# Altium schematic sheet EBoard_UI_LCD16x2_r1.SchDoc
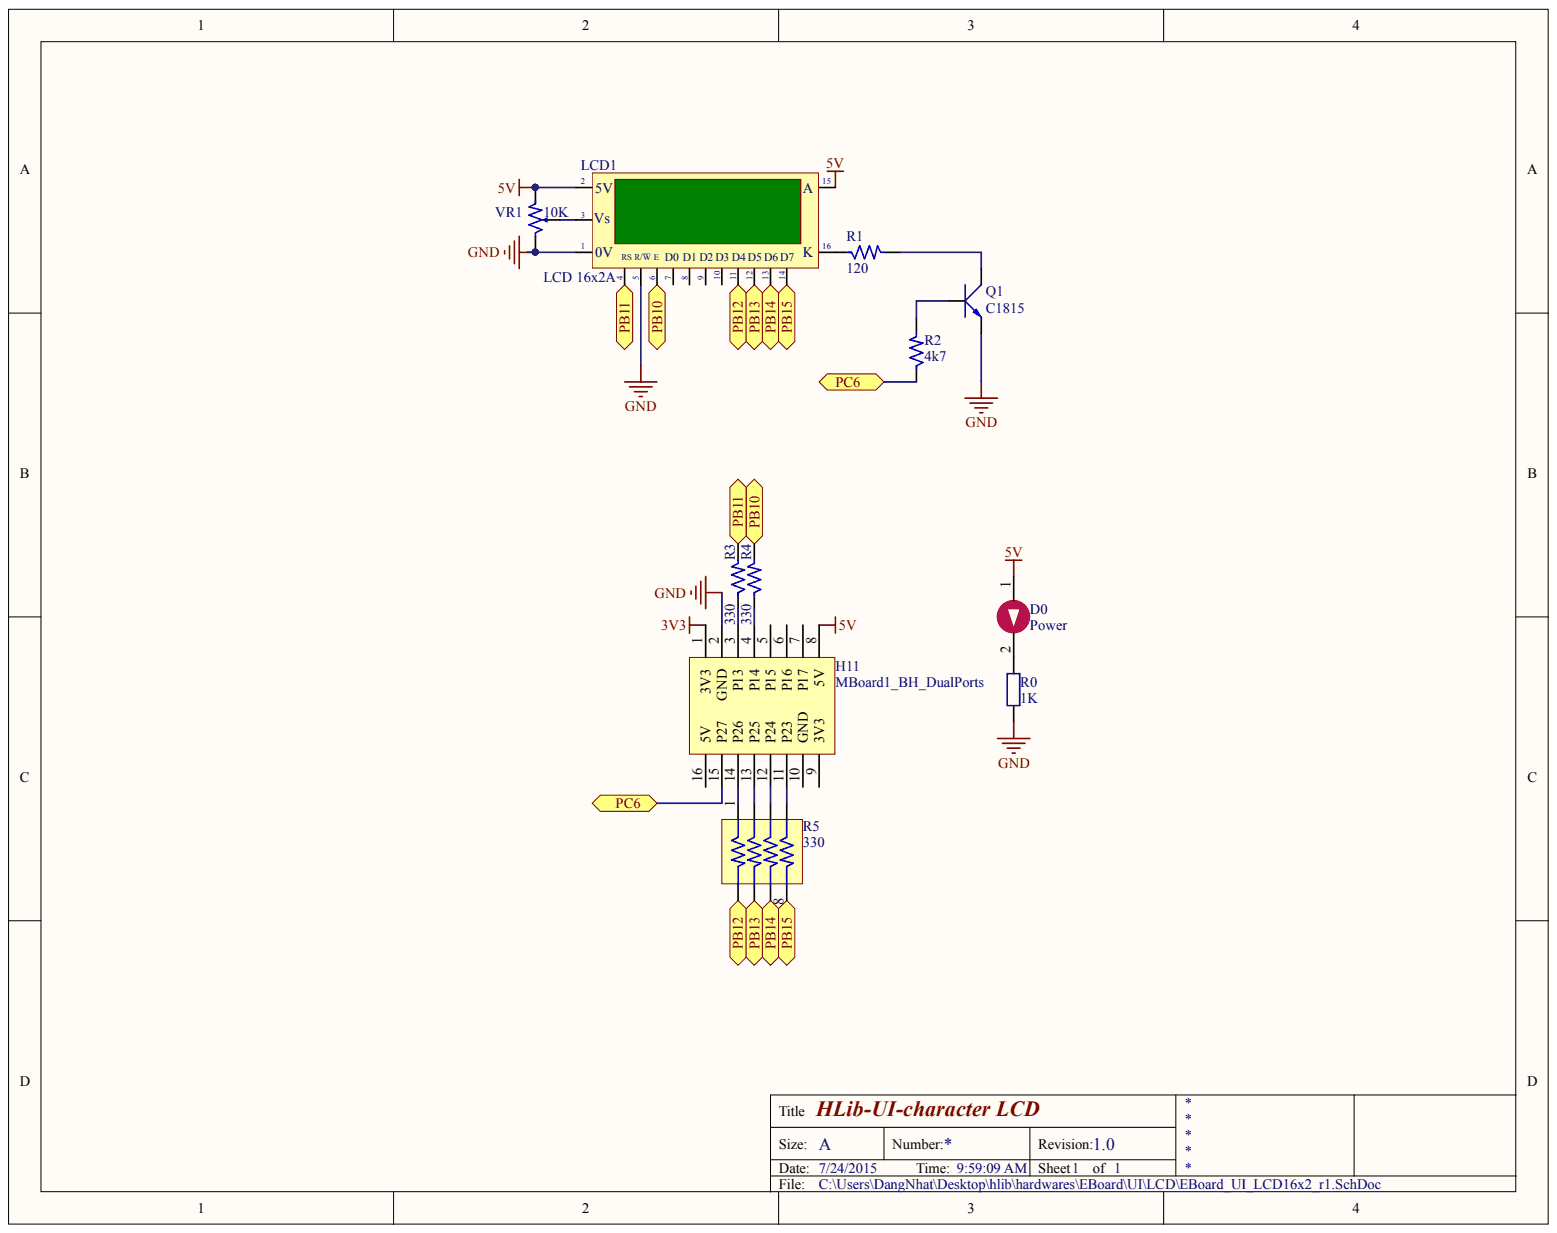
[diagram p0: EBoard_UI_LCD16x2_r1.SchDoc]

## Parts
D0  Power  [SMD0805]
H11  MBoard1_BH_DualPorts  [MBoard1_BH_DualPorts]
LCD1  LCD 16x2A  [LCD16X2]
Q1  C1815  [SOT23B]
R0  R  value 1K  [SMD0805R]
R1  120  [SMD0805R]
R2  4k7  [SMD0805R]
R3  330  [SMD0805R]
R4  330  [SMD0805R]
R5  330  [RA4]
VR1  10K  [VR1]

## Ports
PB10, PB11, PB12, PB13, PB14, PB15, PC6

## Nets
3V3: H11.1(3V3)
5V: D0.1 H11.8(5V) LCD1.2(5V) LCD1.15(A) VR1.1
GND: H11.2(GND) LCD1.1(0V) LCD1.5 Q1.1 R0.1 VR1.3
PB10: LCD1.6(E) R4.2 ; port PB10
PB11: LCD1.4(RS) R3.2 ; port PB11
PB12: LCD1.11(D4) R5.2 ; port PB12
PB13: LCD1.12(D5) R5.4 ; port PB13
PB14: LCD1.13(D6) R5.6 ; port PB14
PB15: LCD1.14(D7) R5.8 ; port PB15
PC6: H11.15(P27) R2.1 ; port PC6
NetD0_2: D0.2 R0.2
NetH11_3: H11.3(P13) R3.1
NetH11_4: H11.4(P14) R4.1
NetH11_11: H11.11(P23) R5.7
NetH11_12: H11.12(P24) R5.5
NetH11_13: H11.13(P25) R5.3
NetH11_14: H11.14(P26) R5.1
NetLCD1_3: LCD1.3(Vs) VR1.2
NetLCD1_16: LCD1.16(K) R1.1
NetQ1_2: Q1.2 R1.2
NetQ1_3: Q1.3 R2.2

Not connected: H11 5(P15) 6(P16) 7(P17) 9(3V3) 10(GND) 16(5V); LCD1 7(D0) 8(D1) 9(D2) 10(D3)

## Library data of the parts
D0 = LEDSMD: LED 3mm; Component Kind: Standard; Library Name: minhLIB.IntLib
LCD1 = LCD16x2A: LCD dipslay 16 char, 2 line; Component Kind: Standard; Value: LCD16x2A; Library Name: minhLIB.IntLib
Q1 = C1815: NPN 600mW, ECB; : C828; : C2383; Component Kind: Standard; Value: C1815; Library Name: VioletofSun.IntLib
R0 = R: RES_08; Component Kind: Standard; LatestRevisionDate: 17-Jul-2002; LatestRevisionNote: Re-released for DXP Platform.; PackageReference: AXIAL-0.4; Published: 8-Jun-2000; Library Name: minhLIB.IntLib; Publisher: Altium Limited
R1-R4 = R: Resistor 1/4W; Value: R1/4W - 1K; Component Kind: Standard
  R1 R2: Library Name: VioletofSun.IntLib
  R3 R4: Library Name: syhaunguyen.IntLib
R5 = RA4: Component Kind: Standard; Library Name: Nhat_Lib.SchLib
VR1 = VR1: Var Resistor; Value: VR 10K; Component Kind: Standard; Library Name: VioletofSun.IntLib
H11 = MBoard1_BH_DualPorts: Component Kind: Standard; Library Name: Nhat_Lib.SchLib
